# Altium schematic sheet BLDC_Motor_Reverser.SchDoc(BLDC_Motor_Reverser)
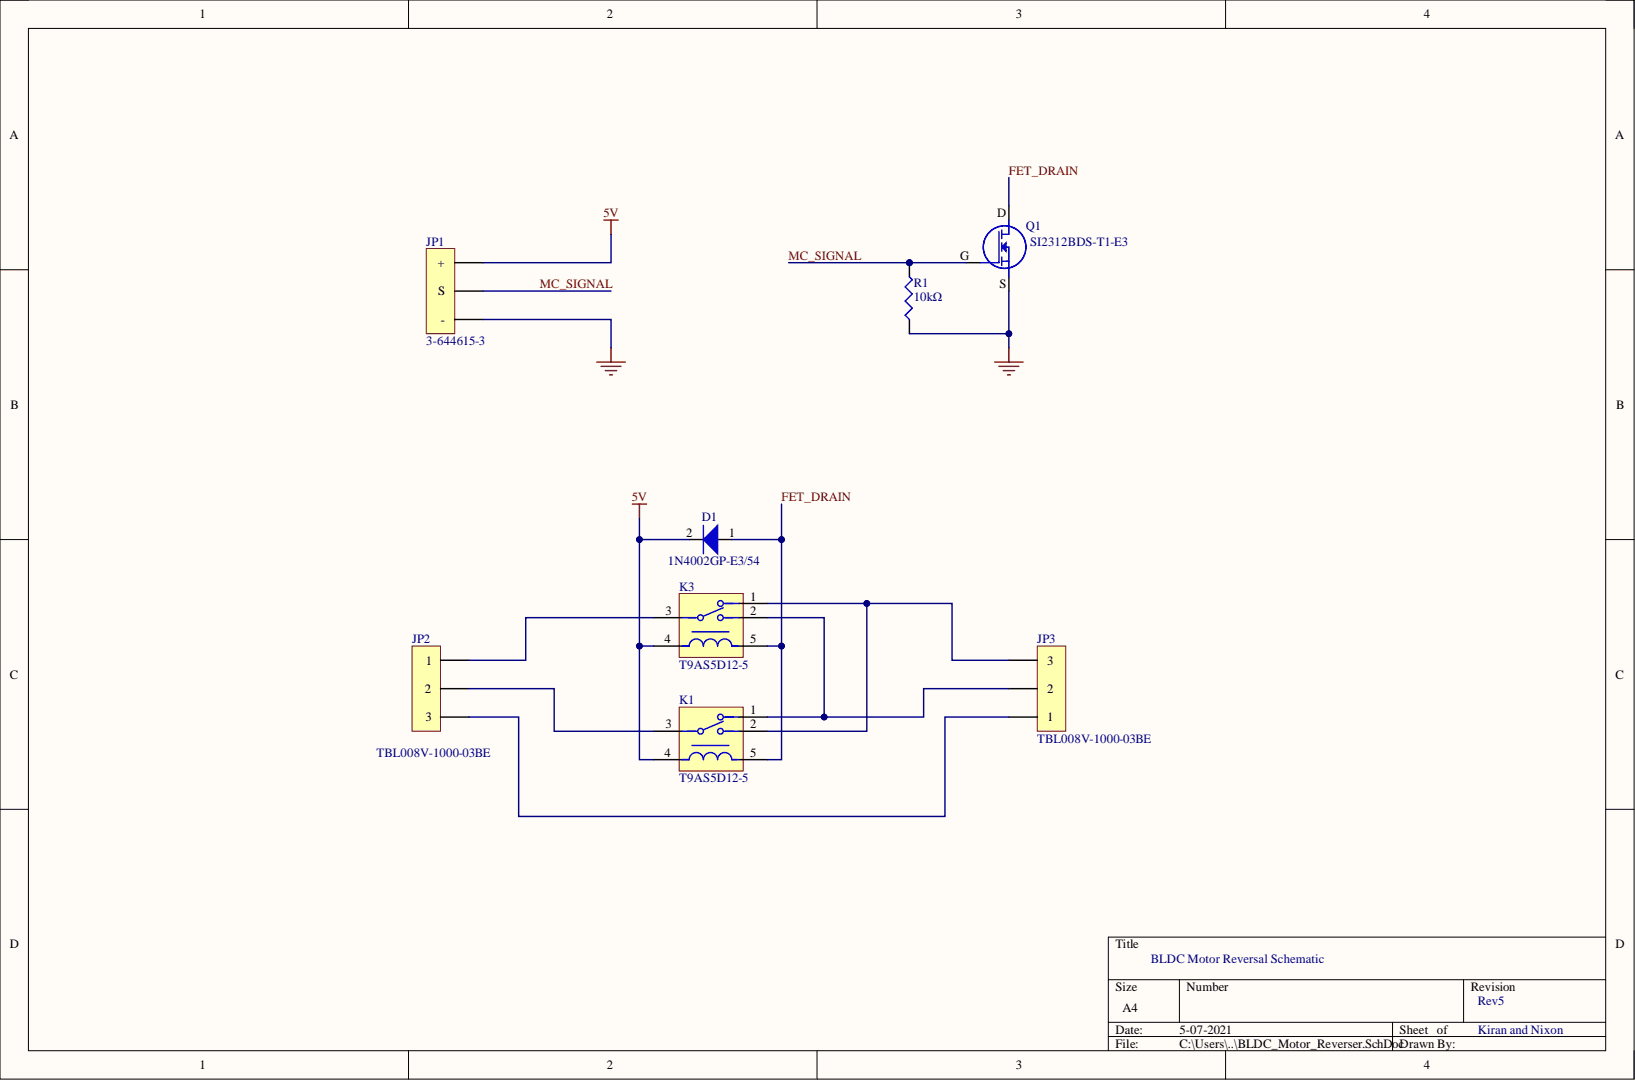
[diagram p0: BLDC_Motor_Reverser.SchDoc]

## Parts
D1  1N4002GP-E3/54
JP1  3-644615-3
JP2  TBL008V-1000-03BE
JP3  TBL008V-1000-03BE
K1  T9AS5D12-5  [FP-T9AS5D12-5-MFG]
K3  T9AS5D12-5  [FP-T9AS5D12-5-MFG]
Q1  SI2312BDS-T1-E3
R1  10kΩ

## Nets
5V: D1.2 JP1.1 K1.4 K3.4
GND: JP1.3 Q1.S R1.1
FET_DRAIN: D1.1 K1.5 K3.5 Q1.D
MC_SIGNAL: JP1.2 Q1.G R1.2
NetJP2_1: JP2.1 K3.3
NetJP2_2: JP2.2 K1.3
NetJP2_3: JP2.3 JP3.1
NetJP3_2: JP3.2 K1.1 K3.2
NetJP3_3: JP3.3 K1.2 K3.1

## Library data of the parts
D1 = 1N4002GP-E3/54: Flyback Diode for SPDT; Component Kind: Standard; Library Name: BLDC_Motor_Lib.SchLib
JP1 = 3-644615-3: Header Pins for power and microcontroller signal; Component Kind: Standard; Library Name: BLDC_Motor_Lib.SchLib
JP2 JP3 = TBL008V-1000-03BE: Terminal Block for 3 Phase lines; Component Kind: Standard; Library Name: BLDC_Motor_Lib.SchLib
K1 K3 = T9AS5D12-5: RELAY GEN PURPOSE SPDT 20A 5V; Coil Current: 200mA; Coil Power: 1W; Coil Resistance: 25R; Coil Type: Non-Latching; Contact Voltage Rating (AC): 277V; Current Rating: 30A; Max Voltage Rating (DC): 28V; Operate Time: 15ms; Relay Type: General Purpose; Coil Voltage (DC): 5V; Component Kind: Standard; Contact Current Rating: 20A; Contact Material: Silver; Height: 20.4mm; Length: 32.5mm; Library Name: BLDC_Motor_Lib.SchLib; Max Operating Temperature: 85°C; Max Voltage Rating (AC): 277V; Min Operating Temperature: -55°C; Mount: Through Hole; Number of Pins: 5; Package Quantity: 50; Packaging: Bulk; Release Time: 15ms; RoHS Compliant: Yes; Series: T9A; Termination: PCB; Throw Configuration: SPDT; Voltage Rating (DC): 5V; Weight: 0.918oz; Width: 27.4mm
R1 = CR0201AJW-103GLF: 10KOhm Resistor (Pulldown Res); Component Kind: Standard; Library Name: BLDC_Motor_Lib.SchLib
Q1 = SI2312BDS-T1-E3: Component Kind: Standard; Library Name: BLDC_Motor_Lib.SchLib
